# Altium schematic sheet TFT_prj_OK.SchDoc(TFT_prj_OK)
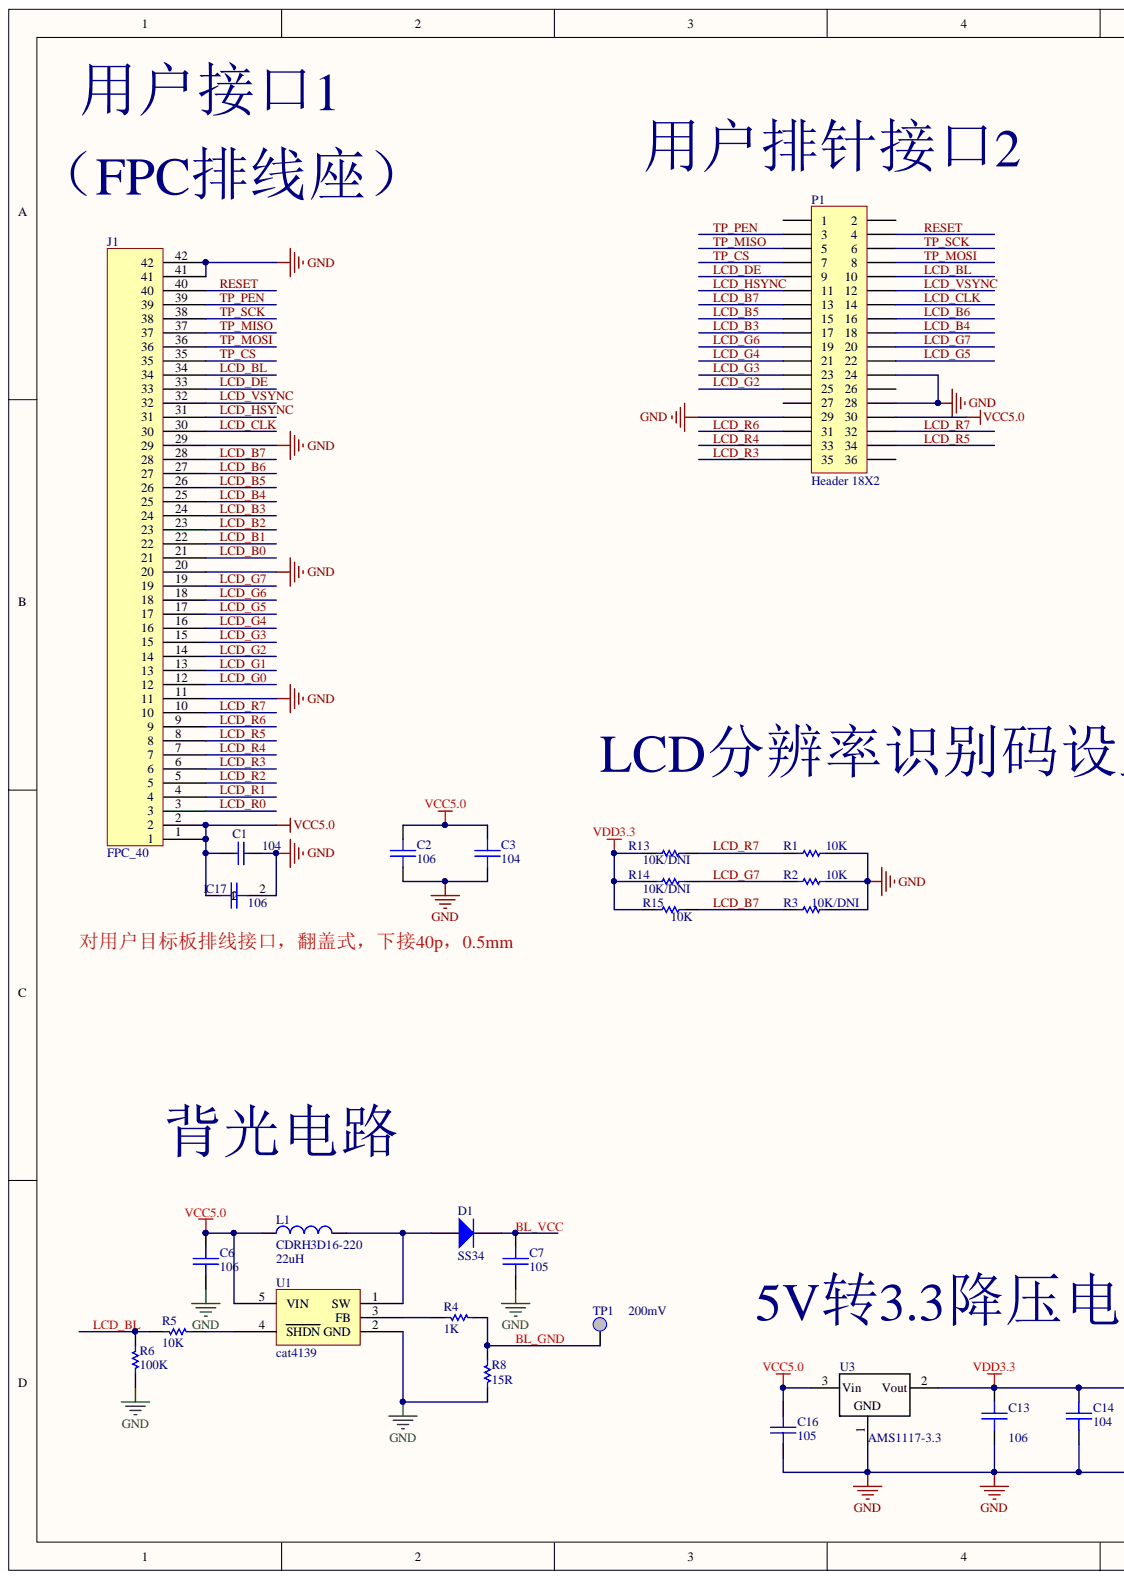
[diagram p0: TFT_prj_OK.SchDoc, left]
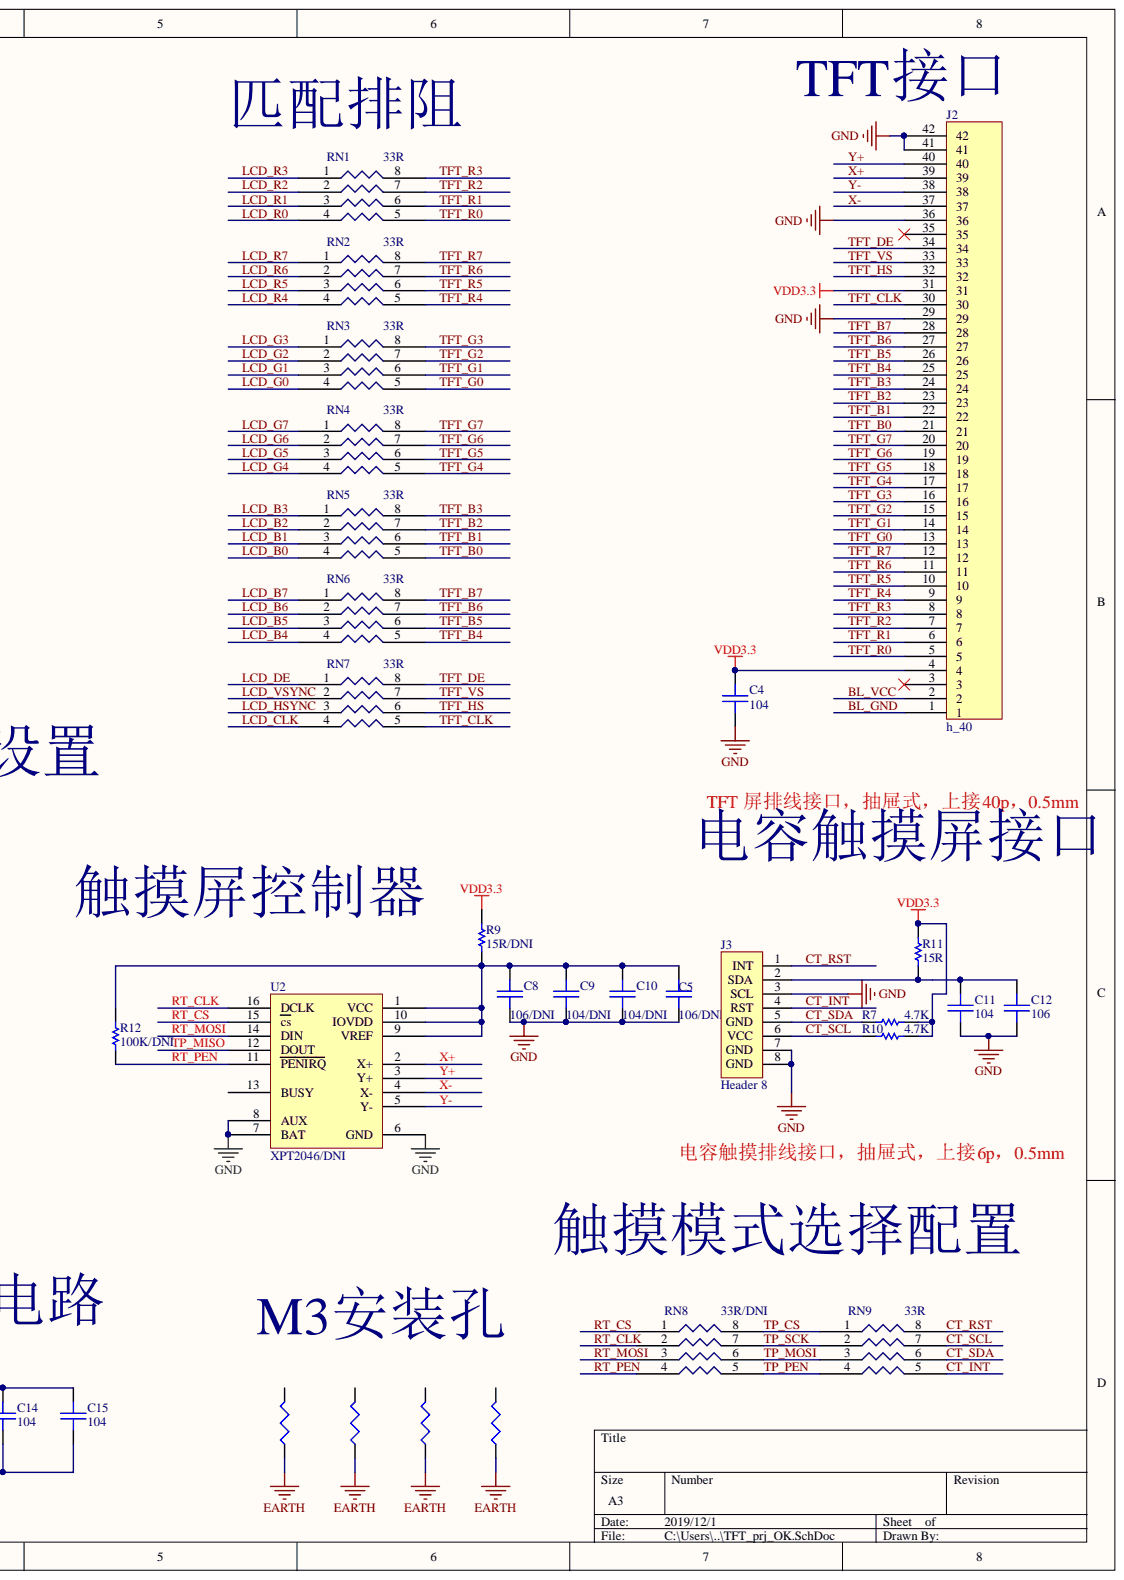
[diagram p1: TFT_prj_OK.SchDoc, right]

## Parts
C1  104  [0603C]
C2  106  [1206]
C3  104  [0603C]
C4  104  [0603C]
C5  106/DNI  [0805C]
C6  106  [1206]
C7  105  [0805C]
C8  106/DNI  [0805C]
C9  104/DNI  [0603C]
C10  104/DNI  [0603C]
C11  104  [0603C]
C12  106  [0805C]
C13  106  [1206]
C14  104  [0603C]
C15  104  [0603C]
C16  105  [1206]
C17  106  [1206]
D1  SS34  [DO-214AC-1]
GND1  RES  [定位孔]  (value not drawn)
GND2  RES  [定位孔]  (value not drawn)
GND3  RES  [定位孔]  (value not drawn)
GND4  RES  [定位孔]  (value not drawn)
J1  FPC_40  [FPC-TOP40]
J2  h_40  [FPC-TOP40]
J3  Header 8  [CTOUCH_6]
L1  CDRH3D16-220  value 22uH  [3*3mm贴片线圈]
P1  Header 18X2  [HDR2X18]
R1  10K  [0603R]
R2  10K  [0603R]
R3  10K/DNI  [0603R]
R4  1K  [0603R]
R5  10K  [0603R]
R6  100K  [0603R]
R7  4.7K  [0603R]
R8  15R  [0603R]
R9  15R/DNI  [0603R]
R10  4.7K  [0603R]
R11  15R  [0603R]
R12  100K/DNI  [0603R]
R13  10K/DNI  [0603R]
R14  10K/DNI  [0603R]
R15  10K  [0603R]
RN1  33R  [0603X4排阻]
RN2  33R  [0603X4排阻]
RN3  33R  [0603X4排阻]
RN4  33R  [0603X4排阻]
RN5  33R  [0603X4排阻]
RN6  33R  [0603X4排阻]
RN7  33R  [0603X4排阻]
RN8  33R/DNI  [0603X4排阻]
RN9  33R  [0603X4排阻]
TP1  200mV  [TEST PAD]
U1  cat4139  [SOT23-5]
U2  XPT2046/DNI  [TSSOP-16]
U3  AMS1117-3.3  [SOT-223]

## Nets
EARTH: GND1.1 GND2.1 GND3.1 GND4.1
GND: C1.2 C2.2 C3.2 C4.2 C5.2 C6.2 C7.2 C8.2 C9.2 C10.1 C11.2 C12.2 C13.2 C14.2 C15.2 C16.2 C17.2 J1.11 J1.20 J1.29 J1.41 J1.42 J2.29 J2.36 J2.41 J2.42 J3.3(SCL) J3.7(GND) J3.8(GND) P1.24 P1.28 P1.29 R1.1 R2.1 R3.1 R6.1 R8.2 U1.2(GND) U2.6(GND) U2.7(BAT) U2.8(AUX) U3.1
VCC5.0: C1.1 C2.1 C3.1 C6.1 C16.1 C17.1 J1.1 J1.2 L1.2 P1.30 U1.5(VIN) U3.3
VDD3.3: C4.1 C13.1 C14.1 C15.1 J2.4 J2.31 R7.1 R9.2 R10.1 R11.2 R13.2 R14.2 R15.2 U3.2
BL_GND: J2.1 R4.2 R8.1 TP1.1
BL_VCC: C7.1 D1.2 J2.2
CT_INT: J3.4(RST) RN9.5
CT_RST: J3.1(INT) RN9.8
CT_SCL: J3.6(VCC) R10.2 RN9.7
CT_SDA: J3.5(GND) R7.2 RN9.6
LCD_B0: J1.21 RN5.4
LCD_B1: J1.22 RN5.3
LCD_B2: J1.23 RN5.2
LCD_B3: J1.24 P1.17 RN5.1
LCD_B4: J1.25 P1.18 RN6.4
LCD_B5: J1.26 P1.15 RN6.3
LCD_B6: J1.27 P1.16 RN6.2
LCD_B7: J1.28 P1.13 R3.2 R15.1 RN6.1
LCD_BL: J1.34 P1.10 R5.1 R6.2
LCD_CLK: J1.30 P1.14 RN7.4
LCD_DE: J1.33 P1.9 RN7.1
LCD_G0: J1.12 RN3.4
LCD_G1: J1.13 RN3.3
LCD_G2: J1.14 P1.25 RN3.2
LCD_G3: J1.15 P1.23 RN3.1
LCD_G4: J1.16 P1.21 RN4.4
LCD_G5: J1.17 P1.22 RN4.3
LCD_G6: J1.18 P1.19 RN4.2
LCD_G7: J1.19 P1.20 R2.2 R14.1 RN4.1
LCD_HSYNC: J1.31 P1.11 RN7.3
LCD_R0: J1.3 RN1.4
LCD_R1: J1.4 RN1.3
LCD_R2: J1.5 RN1.2
LCD_R3: J1.6 P1.35 RN1.1
LCD_R4: J1.7 P1.33 RN2.4
LCD_R5: J1.8 P1.34 RN2.3
LCD_R6: J1.9 P1.31 RN2.2
LCD_R7: J1.10 P1.32 R1.2 R13.1 RN2.1
LCD_VSYNC: J1.32 P1.12 RN7.2
RESET: J1.40 P1.4
RT_CLK: RN8.2 U2.16(DCLK)
RT_CS: RN8.1 U2.15(cs)
RT_MOSI: RN8.3 U2.14(DIN)
RT_PEN: R12.2 RN8.4 U2.11(PENIRQ)
TFT_B0: J2.21 RN5.5
TFT_B1: J2.22 RN5.6
TFT_B2: J2.23 RN5.7
TFT_B3: J2.24 RN5.8
TFT_B4: J2.25 RN6.5
TFT_B5: J2.26 RN6.6
TFT_B6: J2.27 RN6.7
TFT_B7: J2.28 RN6.8
TFT_CLK: J2.30 RN7.5
TFT_DE: J2.34 RN7.8
TFT_G0: J2.13 RN3.5
TFT_G1: J2.14 RN3.6
TFT_G2: J2.15 RN3.7
TFT_G3: J2.16 RN3.8
TFT_G4: J2.17 RN4.5
TFT_G5: J2.18 RN4.6
TFT_G6: J2.19 RN4.7
TFT_G7: J2.20 RN4.8
TFT_HS: J2.32 RN7.6
TFT_R0: J2.5 RN1.5
TFT_R1: J2.6 RN1.6
TFT_R2: J2.7 RN1.7
TFT_R3: J2.8 RN1.8
TFT_R4: J2.9 RN2.5
TFT_R5: J2.10 RN2.6
TFT_R6: J2.11 RN2.7
TFT_R7: J2.12 RN2.8
TFT_VS: J2.33 RN7.7
TP_CS: J1.35 P1.7 RN8.8 RN9.1
TP_MISO: J1.37 P1.5 U2.12(DOUT)
TP_MOSI: J1.36 P1.8 RN8.6 RN9.3
TP_PEN: J1.39 P1.3 RN8.5 RN9.4
TP_SCK: J1.38 P1.6 RN8.7 RN9.2
X+: J2.39 U2.2(X+)
X-: J2.37 U2.4(X-)
Y+: J2.40 U2.3(Y+)
Y-: J2.38 U2.5(Y-)
NetC5_1: C5.1 C8.1 C9.1 C10.2 R9.1 R12.1 U2.1(VCC) U2.9(VREF) U2.10(IOVDD)
NetC11_1: C11.1 C12.1 J3.2(SDA) R11.1
NetD1_1: D1.1 L1.1 U1.1(SW)
NetR4_1: R4.1 U1.3(FB)
NetR5_2: R5.2 U1.4(SHDN)

Not connected: GND1 2; GND2 2; GND3 2; GND4 2; J2 3 35; P1 1 2 26 27 36; U2 13(BUSY)

## Library data of the parts
C1 = Cap: Capacitor; Component Kind: Standard; LatestRevisionDate: 17-Jul-2002; LatestRevisionNote: Re-released for DXP Platform.; PackageReference: RAD-0.3; Published: 8-Jun-2000; Simulation: CAP; Value: 104; Library Name: 元件库封装.pcblib; Publisher: Altium Limited
C2-C17 = 1.02.17.0005: 15PF,±5%,50V,COG,0402,RoHS; Database Table Name: VErpDataInfo; 名称: 电容; 封装: 0402; 设备封装名称: C0402_15PF_COG_50V_5%; 贴片和直插: SMT; Component Kind: Standard; ComponentLink1Description: ErpDataInfo; ComponentLink1URL: http://erp.zlgmcu.com/ErpExt/ErpData/ListMateriel.aspx?pkey=1.02.17.0005; Library Name: ErpMaterialData.DbLib; RoHS: RoHS; 型号: 15PF,±5%,50V,COG; 物料代码: 1.02.17.0005
  C2 C6 C12 C13 C17: Value: 106
  C3 C4 C11 C14 C15: Value: 104
  C5 C8: Value: 106/DNI
  C7 C16: Value: 105
  C9 C10: Value: 104/DNI
D1 = Diode: Default Diode; Code_JEDEC: DO-214-AB; Component Kind: Standard; LatestRevisionDate: 17-Jul-2002; LatestRevisionNote: Re-released for DXP Platform.; Library Name: Miscellaneous Devices.IntLib; PackageReference: SMA; PackageVersion: Sep-1996; Published: 8-Jun-2000; Publisher: Altium Limited
J1 = h_40: Component Kind: Standard; Library Name: NEW.SchLib
J3 = Header 8: Header, 8-Pin; Component Kind: Standard; LatestRevisionDate: 17-Jul-2002; LatestRevisionNote: Re-released for DXP Platform.; Library Name: Miscellaneous Connectors.IntLib; Publisher: Altium Limited
L1 = Inductor: Code_IEC: 1005; Value: 22uH; Code_JEITA: 0402; Component Kind: Standard; Description: Inductor; LatestRevisionDate: 29-May-2009; LatestRevisionNote: IPC-7351 Footprint Added.; Library Name: Miscellaneous Devices.IntLib; PackageReference: CDRH3D16; Published: 8-Jun-2000; Publisher: Altium Limited
P1 = Header 18X2: Header, 18-Pin, Dual row; Component Kind: Standard; LatestRevisionDate: 17-Jul-2002; LatestRevisionNote: Re-released for DXP Platform.; Library Name: Miscellaneous Connectors.IntLib; Publisher: Altium Limited
R1-R15 = Res1: Resistor; Component Kind: Standard; LatestRevisionDate: 17-Jul-2002; LatestRevisionNote: Re-released for DXP Platform.; Library Name: Miscellaneous Devices.IntLib; PackageDescription: Axial Device, Thru-Hole; 2 Leads; 0.3 in Pin Spacing; PackageReference: AXIAL-0.3; Published: 8-Jun-2000; Publisher: Altium Limited
  R1 R2 R5 R15: Simulation: RESISTOR; Value: 10K
  R3 R13 R14: Simulation: RESISTOR; Value: 10K/DNI
  R4: Value: 1K
  R6: Simulation: RESISTOR; Value: 100K
  R7 R10: Simulation: RESISTOR; Value: 4.7K
  R8 R11: Simulation: RESISTOR; Value: 15R
  R9: Simulation: RESISTOR; Value: 15R/DNI
  R12: Simulation: RESISTOR; Value: 100K/DNI
RN1-RN9 = RES_PACKET4: Component Kind: Standard; Library Name: USB302.Lib
  RN1-RN7 RN9: Value: 33R
  RN8: Value: 33R/DNI
TP1 = TestPoint: Component Kind: Standard; Library Name: USB302.Lib
U1 = cat: Component Kind: Standard; Library Name: NEW.SchLib
U2 = TSC204: Component Kind: Standard; Library Name: NEW.SchLib
U3 = AMS1117: Component Kind: Standard; Library Name: user.SchLib
GND1-GND4 = RES: : 0.47UH 电感; Component Kind: Standard; Library Name: NEW.SchLib
J2 = h_40: Component Kind: Standard; Library Name: NEW.SchLib
